# Altium schematic sheet Z21 - ESP32 Holder.SchDoc("Z21 - ESP32 Holder")
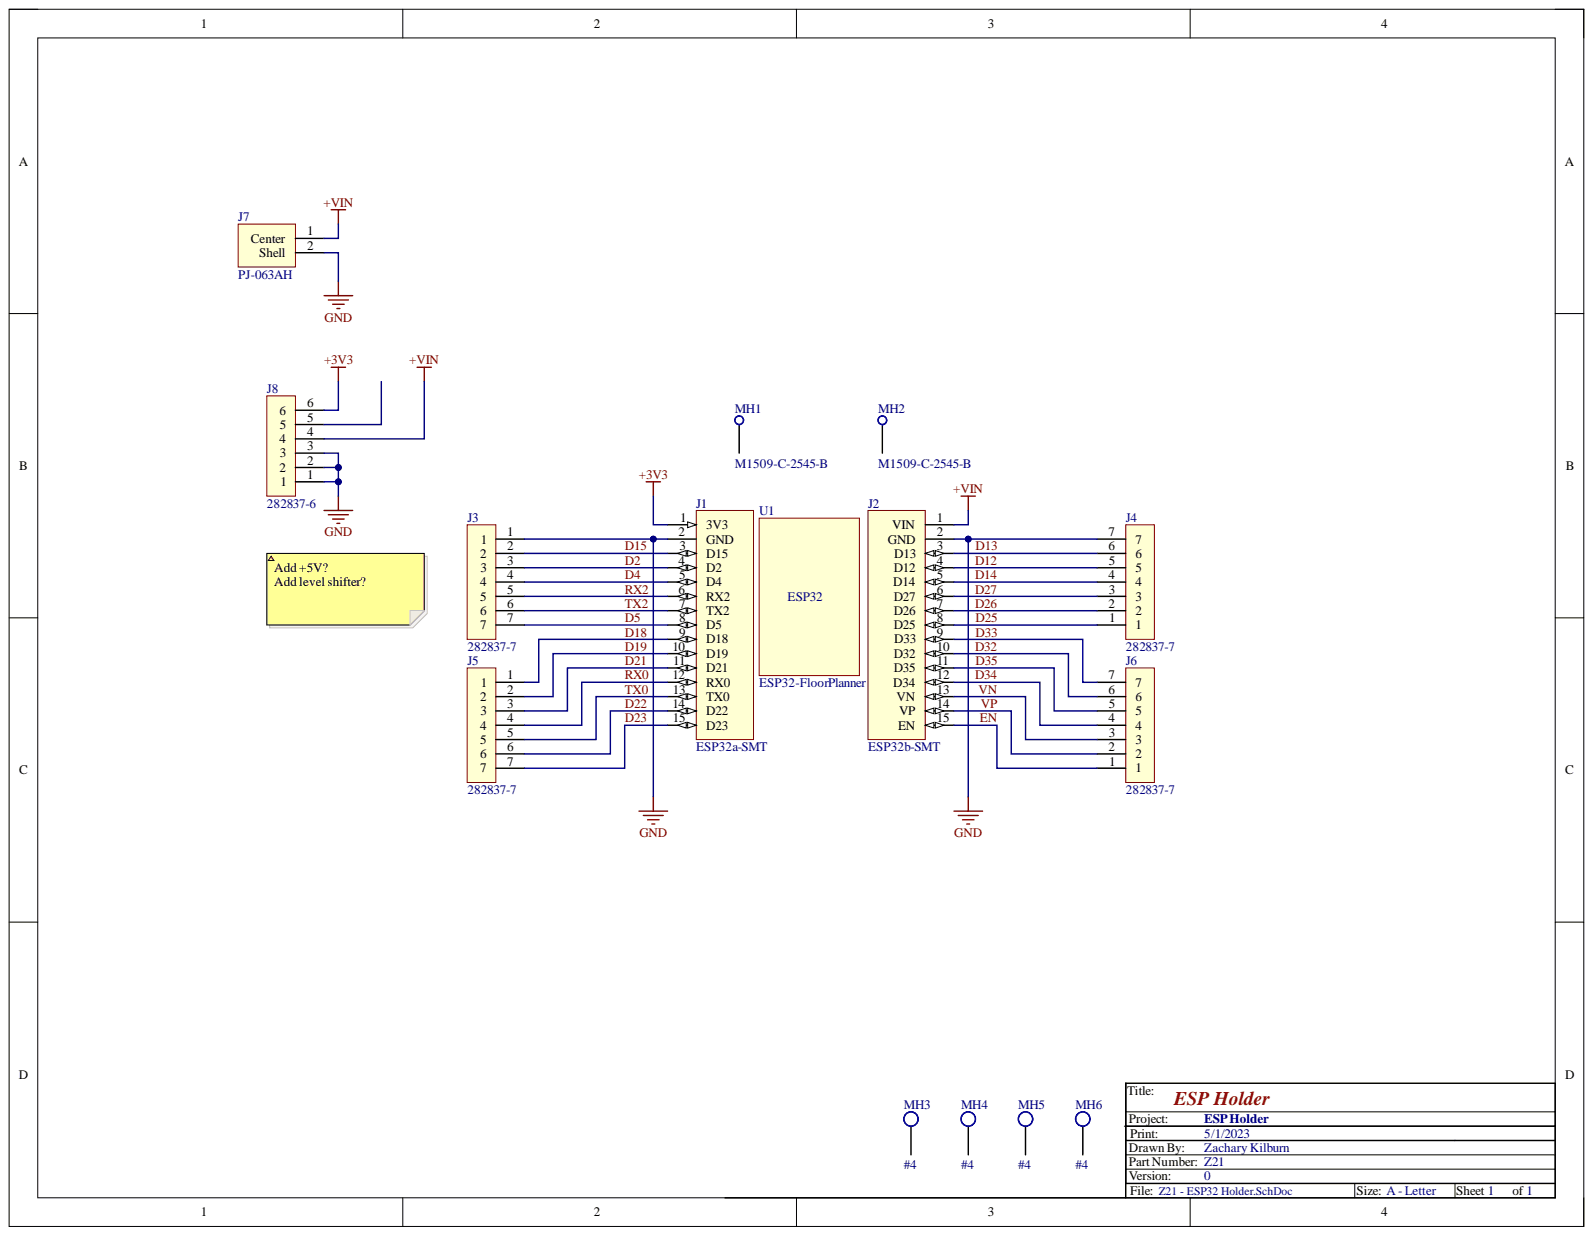
[diagram p0: Z21 - ESP32 Holder.SchDoc]

## Parts
J1  ESP32a-SMT  [PCC-SAMT-SSW-115-01-G-S-VS]
J2  ESP32b-SMT  [PCC-SAMT-SSW-115-01-G-S-VS]
J3  282837-7  [PCC-TE-282837-7-R]
J4  282837-7  [PCC-TE-282837-7-R]
J5  282837-7  [PCC-TE-282837-7-R]
J6  282837-7  [PCC-TE-282837-7-R]
J7  PJ-063AH  [PCC-CUI-PJ-063AH]
J8  282837-6  [PCC-TE-282837-6-R]
MH1  M1509-C-2545-B  [PCC-RAF-M1509-C-2545-B]
MH2  M1509-C-2545-B  [PCC-RAF-M1509-C-2545-B]
MH3  #4  [PCC-XXX-MH-#4-ROUND-PLATED-H128P230M290]  (no BOM)
MH4  #4  [PCC-XXX-MH-#4-ROUND-PLATED-H128P230M290]  (no BOM)
MH5  #4  [PCC-XXX-MH-#4-ROUND-PLATED-H128P230M290]  (no BOM)
MH6  #4  [PCC-XXX-MH-#4-ROUND-PLATED-H128P230M290]  (no BOM)
U1  ESP32-FloorPlanner  [PCC-ESP32]

## Nets
+3V3: J1.1(3V3) J8.6
+VIN: J2.1(VIN) J7.1 J8.4
GND: J1.2(GND) J2.2(GND) J3.1 J4.7 J7.2 J8.1 J8.2 J8.3
D2: J1.4(D2) J3.3
D4: J1.5(D4) J3.4
D5: J1.8(D5) J3.7
D12: J2.4(D12) J4.5
D13: J2.3(D13) J4.6
D14: J2.5(D14) J4.4
D15: J1.3(D15) J3.2
D18: J1.9(D18) J5.1
D19: J1.10(D19) J5.2
D21: J1.11(D21) J5.3
D22: J1.14(D22) J5.6
D23: J1.15(D23) J5.7
D25: J2.8(D25) J4.1
D26: J2.7(D26) J4.2
D27: J2.6(D27) J4.3
D32: J2.10(D32) J6.6
D33: J2.9(D33) J6.7
D34: J2.12(D34) J6.4
D35: J2.11(D35) J6.5
EN: J2.15(EN) J6.1
RX0: J1.12(RX0) J5.4
RX2: J1.6(RX2) J3.5
TX0: J1.13(TX0) J5.5
TX2: J1.7(TX2) J3.6
VN: J2.13(VN) J6.3
VP: J2.14(VP) J6.2

Not connected: J8 5; MH1 0; MH2 0; MH3 1; MH4 1; MH5 1; MH6 1

## Library data of the parts
J1 = ESP32a-SMT: Samtec SSW SMT Socket, ESP32 Pin Set; Component Kind: Standard; ComponentLink1Description: Product URL; ComponentLink1URL: https://www.samtec.com/products/ssw; ComponentLink2Description: Catalog Page; ComponentLink2URL: https://suddendocs.samtec.com/catalog_english/ssw_sm.pdf; ComponentLink3Description: Package Specification; ComponentLink3URL: https://suddendocs.samtec.com/prints/ssw-svs.pdf; Library Name: BoardInterfaces.SchLib
J2 = ESP32b-SMT: Samtec SSW SMT Socket, ESP32 Pin Set; Component Kind: Standard; ComponentLink1Description: Catalog Page; ComponentLink1URL: https://suddendocs.samtec.com/catalog_english/ssw_sm.pdf; ComponentLink2Description: Product URL; ComponentLink2URL: https://www.samtec.com/products/ssw; ComponentLink3Description: Package Specification; ComponentLink3URL: https://suddendocs.samtec.com/prints/ssw-svs.pdf; Library Name: BoardInterfaces.SchLib
J3-J6 = TE-282837-7: PCB Terminal Block, Screw Terminals, 7 Position, 5.08mm Pitch, Through-Hole; Manufacturer: TE Connectivity; Positions: 7; Component Kind: Standard; ComponentLink1Description: Package Specification; ComponentLink1URL: https://www.te.com/commerce/DocumentDelivery/DDEController?Action=showdoc&DocId=Customer+Drawing%7F282837%7FH%7Fpdf%7FEnglish%7FENG_CD_282837_H.pdf%7F282837-7; ComponentLink2Description: Product URL; ComponentLink2URL: https://www.te.com/usa-en/product-282837-7.html?te_bu=Cor&te_type=srch&te_campaign=ggl_usa_cor-ggl-usa-srch-selectmktg-fy21-googlefeed_sma_sma-1735_94&elqCampaignId=95278&mkwid=Aqqp8d7Q%7Cpcrid%7C386964346949%7Cpkw%7C%7Cpmt%7C%7Cpdv%7Cc%7Cslid%7C%7Cproductid%7C282837-7%7Cpgrid%7C78782457963%7Cptaid%7Cpla-301362894879%7C&utm_content=Aqqp8d7Q%7Cpcrid%7C386964346949%7Cpkw%7C%7Cpmt%7C%7Cpdv%7Cc%7Cslid%7C%7Cproductid%7C282837-7%7Cpgrid%7C78782457963%7Cptaid%7Cpla-301362894879&gclid=CjwKCAjwg4-EBhBwEiwAzYAlsqRbXfiTpvkSe6tVdRd2S8Z67aAEzAAk3q1hEV2b2QM3VzFdySyO3RoCnrIQAvD_BwE; ComponentLink3Description: Manufacturer URL; ComponentLink3URL: https://www.te.com/usa-en/home.html; Library Name: Connectors.SchLib; Pitch: 5.08mm; Type: Wire to Board
J7 = CMP-CUI-PJ-063AH: Barrel Jack, 2.1mm x 5.5mm, 24VDC, 8A, Through-Hole, Right Angle; Component Kind: Standard; ComponentLink1Description: Product URL; ComponentLink1URL: https://www.cuidevices.com/product/interconnect/connectors/dc-power-connectors/jacks/pj-063ah; ComponentLink2Description: Manufacturer URL; ComponentLink2URL: https://www.cuidevices.com/; Library Name: Barrel Jacks.SchLib
J8 = TE-282837-6: PCB Terminal Block, Screw Terminals, 6 Position, 5.08mm Pitch, Through-Hole; Manufacturer: TE Connectivity; Positions: 6; Component Kind: Standard; ComponentLink1Description: Package Specification; ComponentLink1URL: https://www.te.com/commerce/DocumentDelivery/DDEController?Action=showdoc&DocId=Customer+Drawing%7F282837%7FH%7Fpdf%7FEnglish%7FENG_CD_282837_H.pdf%7F282837-7; ComponentLink2Description: Product URL; ComponentLink2URL: https://www.te.com/usa-en/product-282837-7.html?te_bu=Cor&te_type=srch&te_campaign=ggl_usa_cor-ggl-usa-srch-selectmktg-fy21-googlefeed_sma_sma-1735_94&elqCampaignId=95278&mkwid=Aqqp8d7Q%7Cpcrid%7C386964346949%7Cpkw%7C%7Cpmt%7C%7Cpdv%7Cc%7Cslid%7C%7Cproductid%7C282837-7%7Cpgrid%7C78782457963%7Cptaid%7Cpla-301362894879%7C&utm_content=Aqqp8d7Q%7Cpcrid%7C386964346949%7Cpkw%7C%7Cpmt%7C%7Cpdv%7Cc%7Cslid%7C%7Cproductid%7C282837-7%7Cpgrid%7C78782457963%7Cptaid%7Cpla-301362894879&gclid=CjwKCAjwg4-EBhBwEiwAzYAlsqRbXfiTpvkSe6tVdRd2S8Z67aAEzAAk3q1hEV2b2QM3VzFdySyO3RoCnrIQAvD_BwE; ComponentLink3Description: Manufacturer URL; ComponentLink3URL: https://www.te.com/usa-en/home.html; Library Name: Connectors.SchLib; Pitch: 5.08mm; Type: Wire to Board
MH1 MH2 = CMP-RAF-M1509-C-2545-B: Swage Standoff, M2.5x0.45, 12mm; Component Kind: Standard; Library Name: Standoffs.SchLib
MH3-MH6 = CMP-000163-2: #4 Mounting Hole, Round, Plated, No Vias; Units: Imperial; Library Name: MountingHoleImperial.SchLib; Size: #4
U1 = ESP32-FloorPlanner: Component Kind: Standard; Library Name: BoardInterfaces.SchLib
